# Altium schematic sheet POWER.SchDoc(POWER)
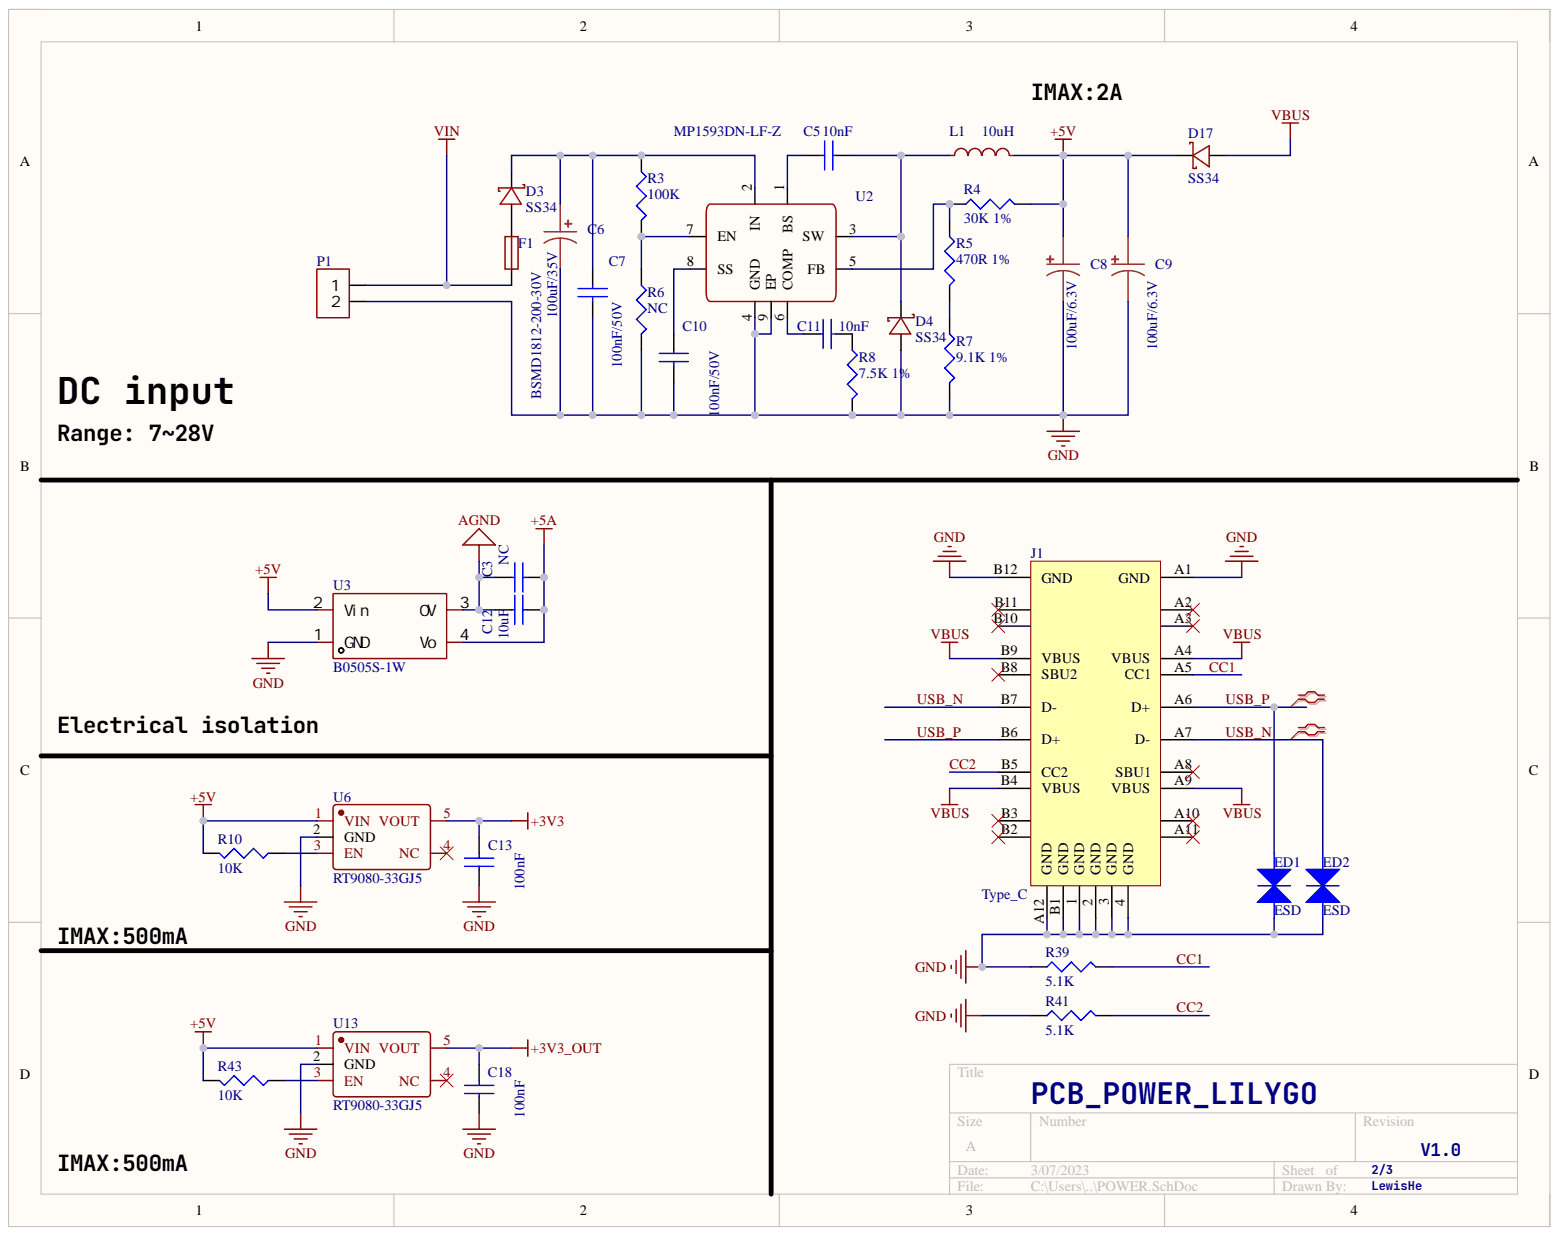
[diagram p0: POWER.SchDoc]

## Parts
C3  NC  [C1206]
C5  10nF  [C0603]
C6  100uF/35V  [CAP-SMD_BD6.3-L6.6-W6.6-LS7.6-FD]
C7  100nF/50V  [C0603]
C8  100uF/6.3V  [CAP-SMD_BD6.3-L6.6-W6.6-LS7.6-FD]
C9  100uF/6.3V  [CAP-SMD_BD6.3-L6.6-W6.6-LS7.6-FD]
C10  100nF/50V  [C0603]
C11  10nF  [C0603]
C12  10uF  [C1206]
C13  100nF  [C0603]
C18  100nF  [C0603]
D3  SS34  [SMA_L4.4-W2.6-LS5.0-RD]
D4  SS34  [SMA_L4.4-W2.6-LS5.0-RD]
D17  SS34  [SMA_L4.4-W2.6-LS5.0-RD]
ED1  ESD  [ESD0402]
ED2  ESD  [ESD0402]
F1  BSMD1812-200-30V  [F1812]
J1  Type_C  [TYPEC_1]
L1  10uH  [IND-SMD_L7.1-W6.6]
P1  WJ500V-5.08-2P  [CONN-TH_2P-P5.00_WJ500V-5.08-2P]  (value not drawn)
R3  100K  [R0402]
R4  30K 1%  [R0402]
R5  470R 1%  [R0402]
R6  NC  [R0402]
R7  9.1K 1%  [R0402]
R8  7.5K 1%  [R0402]
R10  10K  [R0402]
R39  5.1K  [R0402]
R41  5.1K  [R0402]
R43  10K  [R0402]
U2  MP1593DN-LF-Z  [SOIC-8_L5.0-W4.0-P1.27-LS6.0-BL-EP2.0]
U3  B0505S-1W  [PWRM-TH_BXXXXS-1W]
U6  RT9080-33GJ5  [TSOT-23-5_L2.9-W1.6-P0.95-LS2.8-BL]
U13  RT9080-33GJ5  [TSOT-23-5_L2.9-W1.6-P0.95-LS2.8-BL]

## Nets
+3V3: C13.2 U6.5(VOUT)
+3V3_OUT: C18.2 U13.5(VOUT)
+5A: C3.2 C12.2 U3.4(Vo)
+5V: C8.1 C9.1 D17.1 L1.2 R4.2 R10.2 R43.2 U3.2(Vin) U6.1(VIN) U13.1(VIN)
AGND: C3.1 C12.1 U3.3(0V)
GND: C6.2 C7.2 C8.2 C9.2 C10.2 C13.1 C18.1 D4.2 ED1.2 ED2.2 J1.1(GND) J1.2(GND) J1.3(GND) J1.4(GND) J1.A1(GND) J1.A12(GND) J1.B1(GND) J1.B12(GND) P1.2 R6.2 R7.2 R8.2 R39.1 R41.1 U2.4(GND) U2.9(EP) U3.1(GND) U6.2(GND) U13.2(GND)
VBUS: D17.2 J1.A4(VBUS) J1.A9(VBUS) J1.B4(VBUS) J1.B9(VBUS)
VIN: F1.1 P1.1
CC1: J1.A5(CC1) R39.2
CC2: J1.B5(CC2) R41.2
USB_N: ED2.1 J1.A7(D-) J1.B7(D-)
USB_P: ED1.1 J1.A6(D+) J1.B6(D+)
NetC5_1: C5.1 D4.1 L1.1 U2.3(SW)
NetC5_2: C5.2 U2.1(BS)
NetC6_1: C6.1 C7.1 D3.1 R3.1 U2.2(IN)
NetC10_1: C10.1 U2.8(SS)
NetC11_1: C11.1 U2.6(COMP)
NetC11_2: C11.2 R8.1
NetD3_2: D3.2 F1.2
NetR3_2: R3.2 R6.1 U2.7(EN)
NetR4_1: R4.1 R5.1 U2.5(FB)
NetR5_2: R5.2 R7.1
NetR10_1: R10.1 U6.3(EN)
NetR43_1: R43.1 U13.3(EN)

Not connected: J1 A2 A3 A8(SBU1) A10 A11 B2 B3 B8(SBU2) B10 B11; U6 4(NC); U13 4(NC)

## Library data of the parts
C3 C5 C7 C10-C13 C18 = CAP NP_9: Manufacture: WALSIN; Manufacturer: VISHAY; Manufacturer #1???: FengHua(风华); Manufacturer_ID: 1,004; Part Number: 0603F104Z250CT; AML P/N: 123-12Z4-1040C; Description #1: 介质材料[COG（NPO）/COH]通用型属I类电容，容量稳定，不随温度、时间和电压变化而变化，适用高频电路。; Description #2: X7R贴片电容属EIA规定的Class 2类材料电容，容量随温度、时间、电压变化而变化。; Descriptions: CAP CERAMIC .1uF -20%/+80% 25V 0603 SMD RoHS walsin; Dielectric: X7R; DNS: 0; Medium material: COG(NP0)/COH/X7R; PCB Footprint: C0805; Rating Voltage: 50(A)Vdc; Tolerance: ±10%; Voltage Rating: 25V; Component Kind: Standard; Datasheet: \\File1-shanghai\sha\Specifications\Datasheets_IC\_Manufacturer\Walsin\Capacitor\MLCC catalogue_200510.pdf; Manufacturer#2: Vishay（威世）; Manufacturer#3: AVX; Part Type: SMD Capacitance（贴片电容）; VoltageRating: 25V
C6 = 10uF: Component Kind: Standard; Library Name: 常用.SCHLIB
C8 C9 = 100uF: Component Kind: Standard; Library Name: 常用.SCHLIB
ED1 ED2 = TVS: Component Kind: Standard; Library Name: MySchlib.SchLib
F1 = BSMD1812-200-30V: 最大电压:30V;最大电流:40A;保持电流:2A;跳闸电流:4A;消耗功率:800mW;初始态阻值(最小值):20mΩ;跳断后阻值(最大值):100mΩ;最大动作时间:2s;工作温度:-40℃~+85℃;; Component Kind: Standard; Library Name: 常用.SCHLIB
J1 = Type_C: TYPE-C3.0-USB; Component Kind: Standard; Library Name: T-SIM7080G.SCHLIB
R3-R8 R10 R39 R41 R43 = Res3: Resistor; Component Kind: Standard; LatestRevisionDate: 29-May-2009; LatestRevisionNote: IPC-7351 Footprint Added.; Library Name: Miscellaneous Devices.IntLib; PackageDescription: Chip Resistor; PackageReference: J1-0603; Published: 8-Jun-2000; Signal Integrity: Res; Simulation: RESISTOR; Publisher: Altium Limited
  R3: Value: 100K
  R4: Value: 30K 1%
  R5: Value: 470R 1%
  R6: Value: NC
  R7: Value: 9.1K 1%
  R8: Value: 7.5K 1%
  R10 R43: Value: 10K
  R39 R41: Value: 5.1K
U2 = MP1593DN-LF-Z: MP1593DN SOP-8; Component Kind: Standard; Library Name: 常用.SCHLIB
U3 = B0505S-1W: DIP 电源模块 B0505S-1W; Component Kind: Standard; Library Name: 常用.SCHLIB
D3 D4 D17 = SS34: Component Kind: Standard; Library Name: 常用.SCHLIB
L1 = 10uH: Component Kind: Standard; Library Name: 常用.SCHLIB
P1 = WJ500V-5.08-2P: Component Kind: Standard; Description: WJ500V-5.08-2P; Library Name: HW_RELAY_MAIN.SCHLIB
U6 U13 = RT9080-33GJ5: Component Kind: Standard; Library Name: 常用.SCHLIB
